# Altium schematic sheet GPS.SchDoc(GPS)
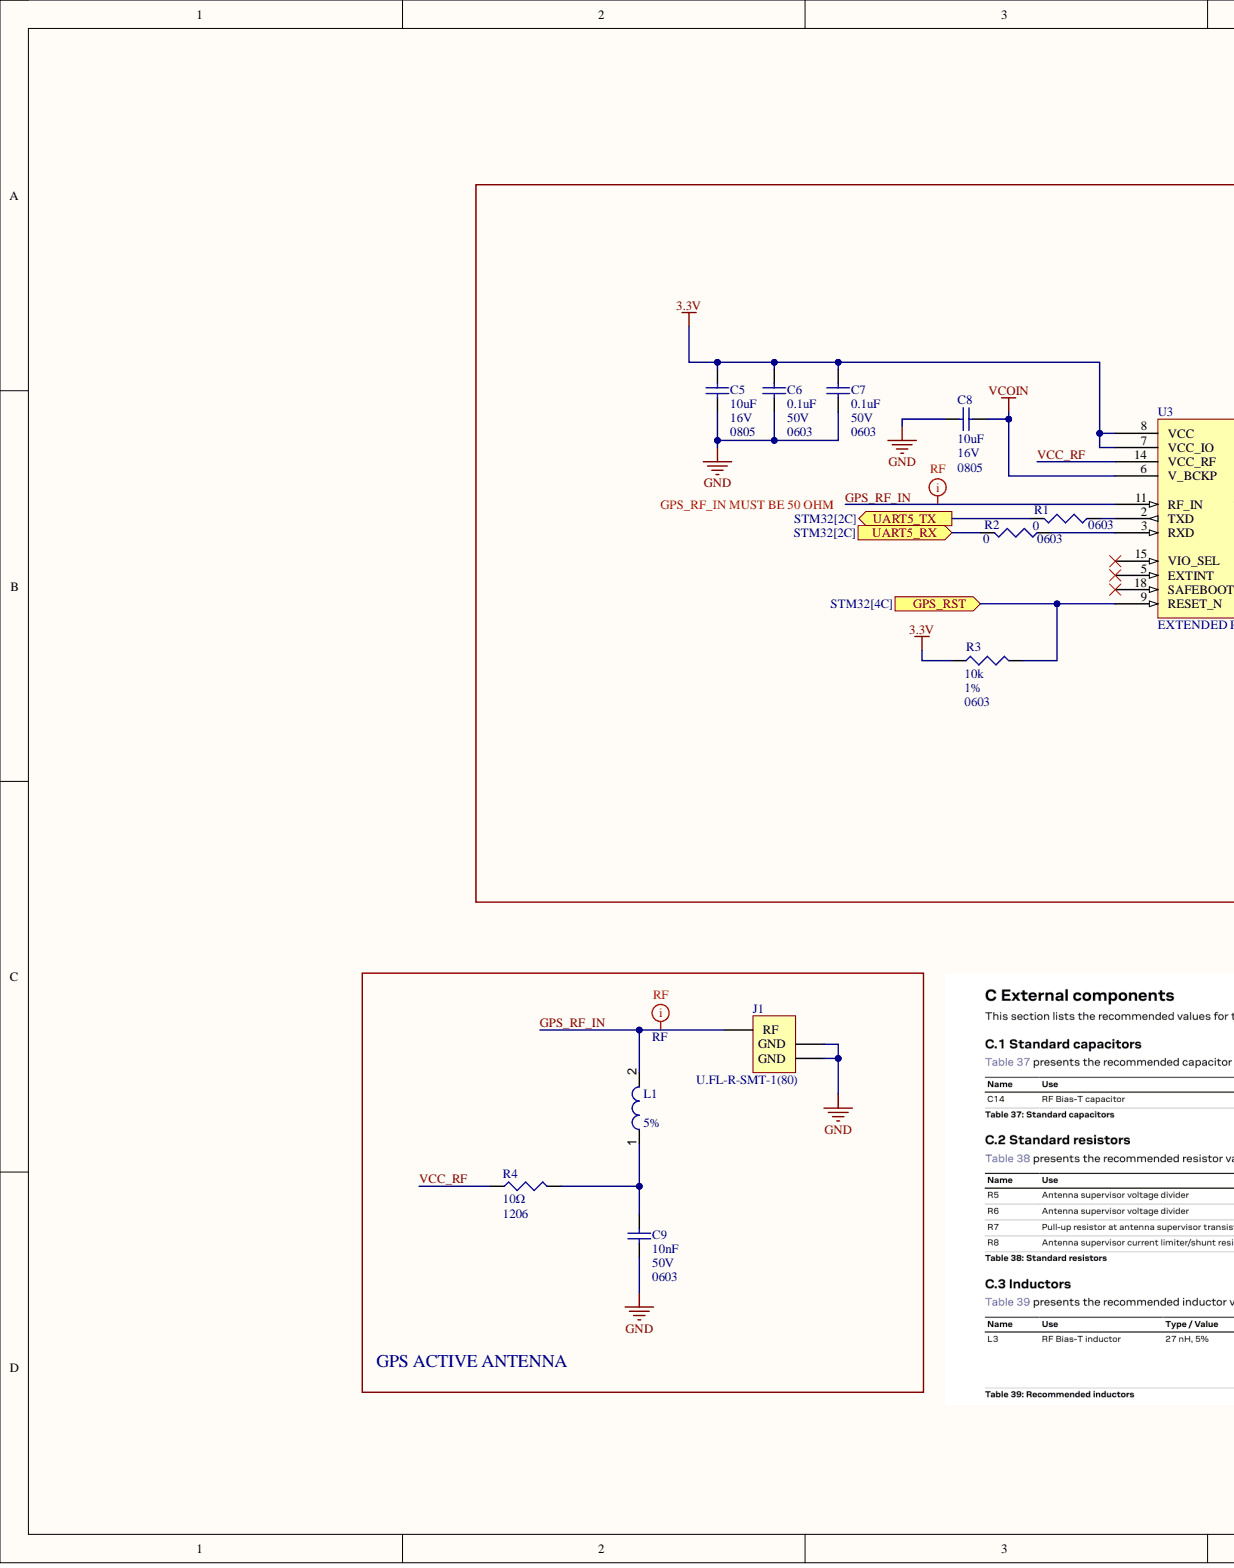
[diagram p0: GPS.SchDoc, left]
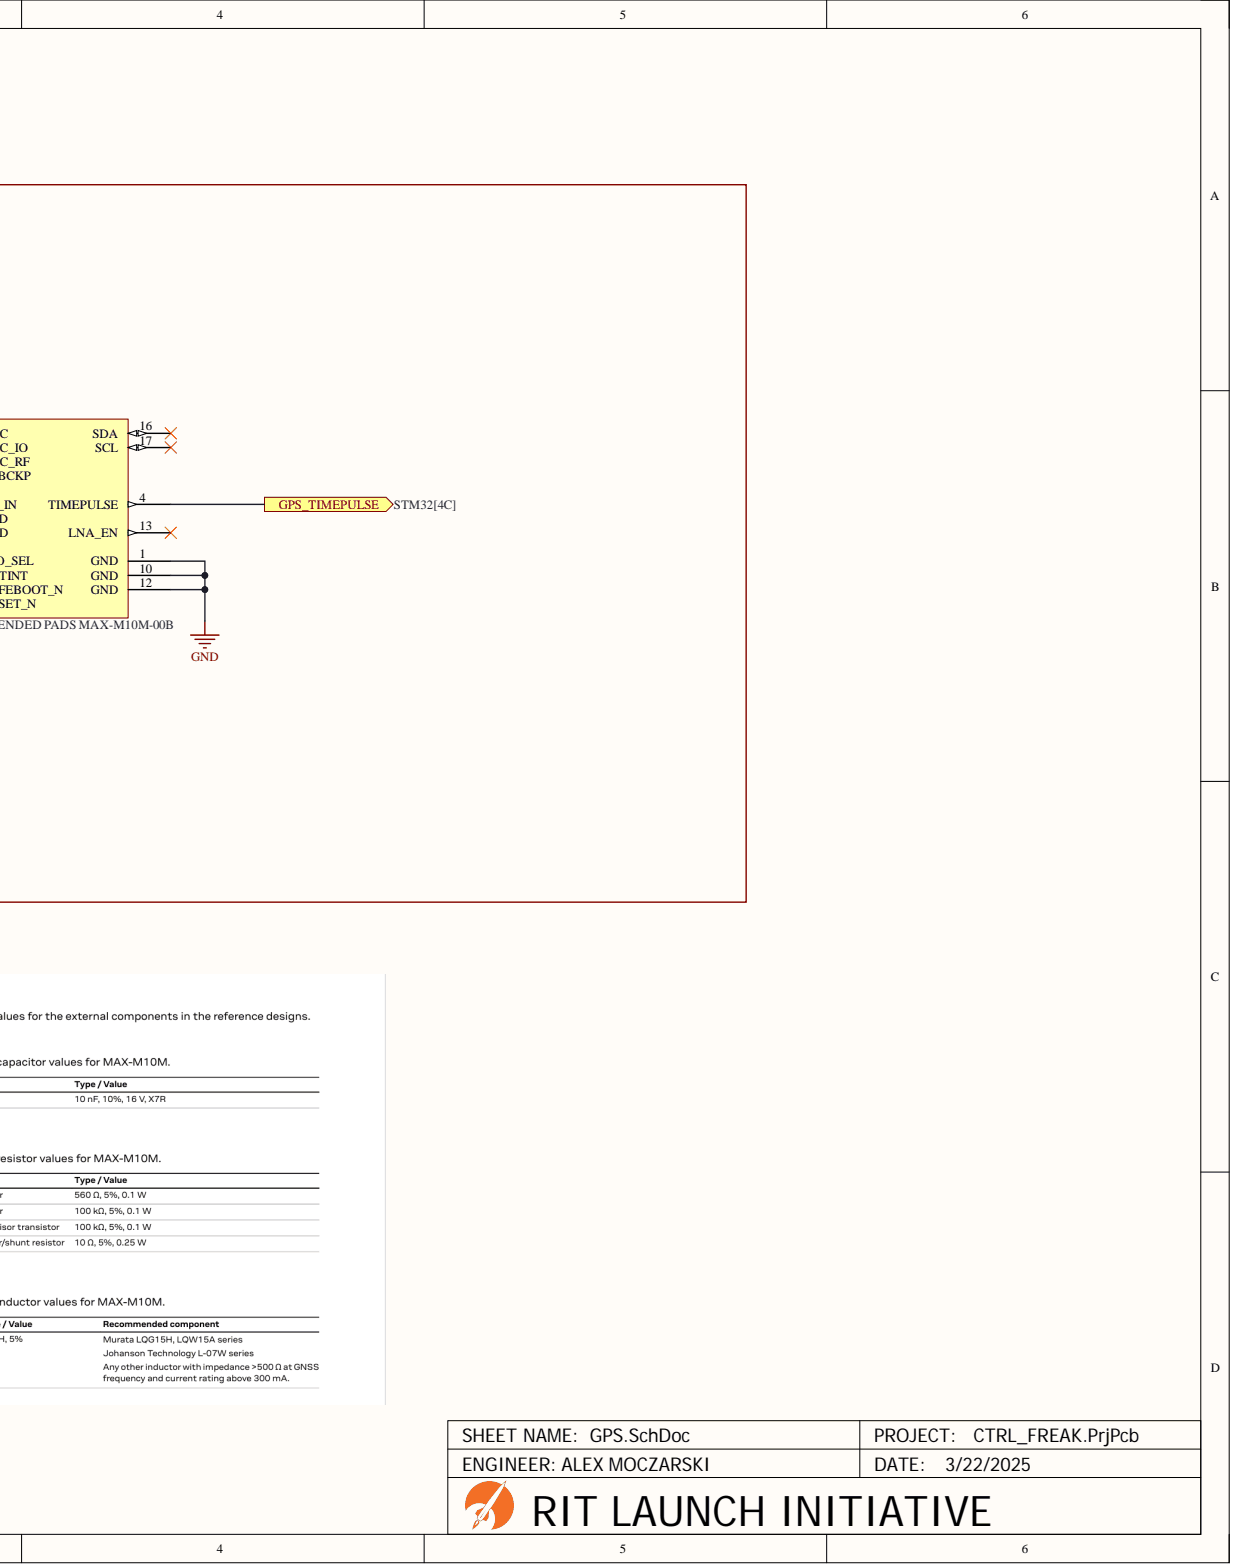
[diagram p1: GPS.SchDoc, right]

## Parts
C5  Capacitance: 10uF  Case Code (Imperial): 0805  Voltage: 16V  (hidden comment: CL21A106KOQNNNE)
C6  Capacitance: 0.1uF  Case Code (Imperial): 0603  Voltage: 50V  (hidden comment: CC0603KRX7R9BB104)
C7  Capacitance: 0.1uF  Case Code (Imperial): 0603  Voltage: 50V  (hidden comment: CC0603KRX7R9BB104)
C8  Capacitance: 10uF  Case Code (Imperial): 0805  Voltage: 16V  (hidden comment: CL21A106KOQNNNE)
C9  Capacitance: 10nF  Case/Package: 0603  Voltage: 50V  (hidden comment: 0603 10nF 50V 10%)
J1  U.FL-R-SMT-1(80)
L1  Tolerance: 5%  (hidden comment: LQG15HS27NJ02D)
R1  Case/Package: 0603  (hidden comment: ERJ-3GEY0R00V)
R2  Case/Package: 0603  (hidden comment: ERJ-3GEY0R00V)
R3  Case/Package: 0603  Resistance: 10k  Tolerance: 1%  (hidden comment: 0603WAF1002T5E)
R4  Case/Package: 1206  Resistance: 10Ω  (hidden comment: 1206W4F100JT5E)
U3  EXTENDED PADS MAX-M10M-00B

## Ports
GPS_RST, GPS_TIMEPULSE, UART5_RX, UART5_TX

## Nets
3.3V: C5.2 C6.2 C7.2 R3.1 U3.7(VCC_IO) U3.8(VCC)
GND: C5.1 C6.1 C7.1 C8.2 C9.1 J1.2 J1.3 U3.1(GND) U3.10(GND) U3.12(GND)
VCOIN: C8.1 U3.6(V_BCKP)
GPS_RF_IN: J1.1 L1.2 U3.11(RF_IN)
GPS_RST: R3.2 U3.9(RESET_N) ; port GPS_RST
GPS_TIMEPULSE: U3.4(TIMEPULSE) ; port GPS_TIMEPULSE
UART5_RX: R2.2 ; port UART5_RX
UART5_TX: R1.2 ; port UART5_TX
VCC_RF: R4.1 U3.14(VCC_RF)
NetC9_2: C9.2 L1.1 R4.2
NetR1_1: R1.1 U3.2(TXD)
NetR2_1: R2.1 U3.3(RXD)

Not connected: U3 5(EXTINT) 13(LNA_EN) 15(VIO_SEL) 16(SDA) 17(SCL) 18(SAFEBOOT_N)

## Library data of the parts
C5 C8 = CMP-001-0207-3: CAP CER 10UF 16V X5R 0805; Tolerance: 10%; Case Code (Metric): 2012; Case/Package: 0805; Component Kind: Standard; Construction: Flat; Depth: 1.25mm; Dielectric: X5R; Height: 1.25mm; JLCPCB Part #: C1713; Lead Free: Lead Free; Length: 2mm; Max Operating Temperature: 85°C; Min Operating Temperature: -55°C; Mount: Surface Mount; Package Quantity: 1; Packaging: Tape and Reel; Radiation Hardening: No; RoHS Compliant: Yes; Series: CL; Thickness: 0.055inch; Voltage Rating: 16V; Voltage Rating (DC): 16V; Width: 0.049inch; Library Name: RIT Launch Initiative
C6 C7 = CMP-001-0242-3: CAP CER 0.1UF 50V X7R 0603; Dielectric Material: Ceramic; Tolerance: 10%; Case Code (Metric): 1608; Case/Package: 0603; Component Kind: Standard; Depth: 0.8mm; Dielectric: X7R; Halogen Free: Halogen Free; Height: 0.8mm; JLCPCB Part #: C14663; Lead Free: Lead Free; Length: 0.063inch; Max Operating Temperature: 125°C; Min Operating Temperature: -55°C; Mount: Surface Mount; Number of Pins: 2; Package Quantity: 1; Packaging: Tape and Reel; Radiation Hardening: No; REACH SVHC: No SVHC; RoHS Compliant: Yes; Series: CC; Termination: SMD/SMT; Thickness: 0.035inch; Voltage Rating: 50V; Width: 0.031inch; Library Name: RIT Launch Initiative
C9 = CMP-001-00096-1: 50V 10nF X7R ±10% 0603 Multilayer Ceramic Capacitors MLCC - SMD/SMT ROHS; Component Kind: Standard; JLCPCB Part #: C57112; Tolerance: 10%; Library Name: RIT Launch Initiative
J1 = CMP-001-0231-4: CONN U.FL RCPT STR 50 OHM SMD; Body Material: Bronze; Body Plating: Silver; Center Contact Plating: Gold; Connector Type: Receptacle,Male Pin; Dielectric Material: Polymer; Impedance: 50R; Mating Cycles: 30; Max Frequency: 6GHz; Number of Ports: 1; Case/Package: SMD/SMT; Component Kind: Standard; Contact Material: Brass; Fastening Type: SNAP-ON; Housing Color: Beige; JLCPCB Part #: C88374; Lead Free: Lead Free; Max Operating Temperature: 90°C; Min Operating Temperature: -40°C; Mount: Surface Mount; Package Quantity: 10000; Packaging: Tape and Reel; RoHS Compliant: Yes; Series: U.FL; Termination: Solder; Library Name: RIT Launch Initiative
L1 = CMP-001-0450-3: General Purpose Inductor, 0.027uH, 5%, 1 Element, Air-Core, SMD, 0402; Case/Size Code: 0402; Channels: 1; Core Material: Air; DC Resistance (DCR): 460mR; Inductor Application: RF INDUCTOR; Max Current Rating: 350mA; Package Height: 0.5mm; Package Length: 1mm; Quality Factor-Min (at L-nom): 8; Self Resonant Frequency: 1.7GHz; Shape/Size Description: RECTANGULAR PACKAGE; Shielding: Unshielded; Test Frequency: 100MHz; Component Kind: Standard; Construction: Multilayer Chip; Inductance-Nom (L): 0.027uH; Inductor Type: GENERAL PURPOSE INDUCTOR; JESD-609 Code: e3; JLCPCB Part #: C12669; Max Operating Temperature: 125°C; Min Operating Temperature: -55°C; Package Style: SMTMeter; Package Width: 0.5mm; Packaging: Tape and Reel; Pins: 2; Reach SVHC Compliant: Yes; RoHS Compliant: Yes; Surface Mount: Yes; Terminal Finish: Nickel; Terminal Placement: Dual Ended; Termination: Wraparound; Library Name: RIT Launch Initiative
R1 R2 = CMP-001-0007-2: RES SMD 0 OHM JUMPER 1/10W 0603; Resistance: 0; Component Kind: Standard; JLCPCB Part #: C122704; Tolerance: --; Library Name: RIT Launch Initiative
R3 = CMP-001-0268-1: RES 10K OHM 1% 1/10W 0603; Component Kind: Standard; JLCPCB Part #: C25804; RoHS Compliant: Yes; Library Name: RIT Launch Initiative
R4 = CMP-001-0406-2: Component Kind: Standard; Description: 1206W4F100JT5E; JLCPCB Part #: C17903; Library Name: RIT Launch Initiative
U3 = CMP-021-00009-1: Gnss Lcc Rx Mod, Rom, Crystal, EXTENDED PADS FOR HAND SOLDERING; Component Kind: Standard; RoHS Compliant: Yes; Library Name: RIT Launch Initiative
